# Altium schematic sheet Sheet1.SchDoc
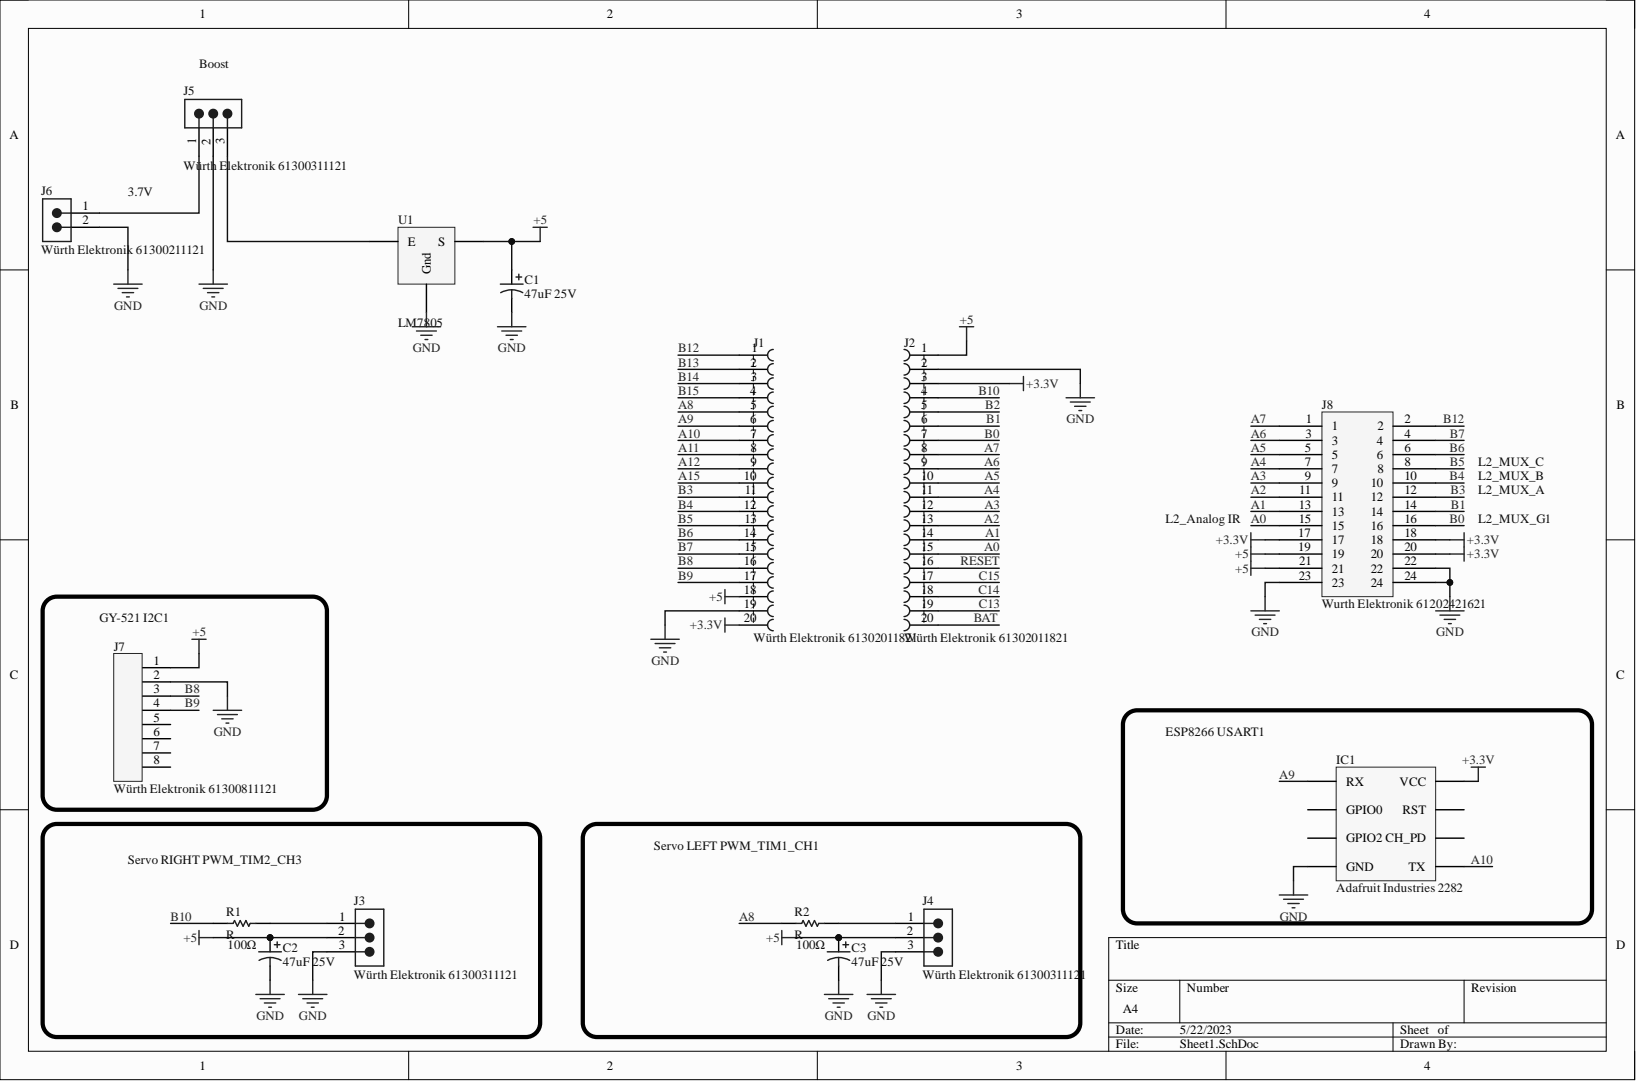
[diagram p0: Sheet1.SchDoc]

## Parts
C1  47uF 25V  [ELCO-6.3-12-2.5]
C2  47uF 25V  [ELCO-6.3-12-2.5]
C3  47uF 25V  [ELCO-6.3-12-2.5]
IC1  Adafruit Industries 2282  Manufacturer Part Number: 2282  [PCB-8dog99dypeufmvpps9vj-1]
J1  Würth Elektronik 61302011821  [PCB-ze9tk72dnivqf9rwp0x5-1]
J2  Würth Elektronik 61302011821  Manufacturer Part Number: 61302011821  [PCB-ze9tk72dnivqf9rwp0x5-1]
J3  Würth Elektronik 61300311121  [Würth Elektronik 61300311121-Footprint-1]
J4  Würth Elektronik 61300311121  [Würth Elektronik 61300311121-Footprint-1]
J5  Würth Elektronik 61300311121  [Würth Elektronik 61300311121-Footprint-1]
J6  Würth Elektronik 61300211121  [Würth Elektronik 61300211121-Footprint-1]
J7  Würth Elektronik 61300811121  [PCB-mwg13fs3p401tzikccr9-1]
J8  Wurth Elektronik 61202421621  Manufacturer Part Number: 61202421621  [Wurth Elektronik 61202421621-Footprint-1]
R1  R  [PCB-q66ntzze7gytxksj4v9p-1]
R2  R  [PCB-q66ntzze7gytxksj4v9p-1]
U1  LM7805  [PCB-8j54e42cjq8e6smjudro-5]

## Nets
+3.3V: IC1.4(VCC) J1.20 J2.3 J8.17 J8.18 J8.20
+5: C1.1 C2.1 C3.1 J1.18 J2.1 J3.2 J4.2 J7.1 J8.19 J8.21 U1.S
GND: C1.2 C2.2 C3.2 IC1.8(GND) J1.19 J2.2 J3.3 J4.3 J5.2 J6.2 J7.2 J8.22 J8.23 J8.24 U1.Gnd
A0: J2.15 J8.15
A1: J2.14 J8.13
A2: J2.13 J8.11
A3: J2.12 J8.9
A4: J2.11 J8.7
A5: J2.10 J8.5
A6: J2.9 J8.3
A7: J2.8 J8.1
A8: J1.5 R2.1
A9: IC1.5(RX) J1.6
A10: IC1.1(TX) J1.7
A11: J1.8
A12: J1.9
A15: J1.10
B0: J2.7 J8.16
B1: J2.6 J8.14
B2: J2.5
B3: J1.11 J8.12
B4: J1.12 J8.10
B5: J1.13 J8.8
B6: J1.14 J8.6
B7: J1.15 J8.4
B8: J1.16 J7.3
B9: J1.17 J7.4
B10: J2.4 R1.1
B12: J1.1 J8.2
B13: J1.2
B14: J1.3
B15: J1.4
BAT: J2.20
C13: J2.19
C14: J2.18
C15: J2.17
RESET: J2.16
NetJ3_1: J3.1 R1.2
NetJ4_1: J4.1 R2.2
NetJ5_1: J5.1 J6.1
NetJ5_3: J5.3 U1.E

Not connected: IC1 2(CH_PD) 3(RST) 6(GPIO0) 7(GPIO2); J7 5 6 7 8

## Library data of the parts
C1-C3 = CMP-f0e23b7c3dc72f25-3: 150uF 6.3mm diameter 2.5mm lead spacing; Manufacturer Part Number: ECE-A1EKA470I; Capacitance: 47.0 µF; Capacitance Tolerance: ±20%; Lead-Free Status: Lead Free; Voltage Rating (DC): 25.0 V; Component Kind: Standard; Library Name: My Personal Space; Lifecycle Status: Not Listed by Manufacturer; Octopart Url: https://octopart.com/ece-a1eka470i-panasonic-43313219; OctopartID: f0e23b7c3dc72f25; Operating Temperature: -40.0 °C (min); Packaging: Cut Tape (CT); REACH SVHC Compliance: No SVHC; RoHS: Compliant; Size-Diameter: 6.30 mm; Size-Height: 7.00 mm
IC1 = CMP-95455f7502034a82-7: Wiremold: Parcel Drops & CollectionFittings & Kits: : Salsbury Industries Mailboxes 2200 Series 2-Compartments Alumin...; Current consumption (Nom/Max): 70mA / 200mA; Voltage supply: 3.3V; Component Kind: Standard; Library Name: My Personal Space; Octopart URL: http://octopart.com/2282-adafruit+industries-54178336; OctopartID: 95455f7502034a82; RoHS: Non-Compliant
J1 J2 = CMP-f0823a38306c2f45-1: THT Vertical Socket Header WR-PHD, Pitch 2.54 mm, Single Row, 20 pins; Contact Resistance: 20.0 mΩ; Current Rating: 3.00 A; Dielectric Withstanding Voltage: 500 V; Gender: Female; Operating Voltage: 250 V; Pin Pitch: 2.54 mm; Component Kind: Standard; Contact Material: Copper Alloy; Contact Plating: Gold; Library Name: My Personal Space; Mounting Style: Through Hole; Number of Pins: 20; Octopart URL: https://octopart.com/61302011821-w%C3%BCrth+elektronik-61924744; OctopartID: f0823a38306c2f45; Operating Temperature: -40.0 °C (min); Orientation: Vertical; Packaging: Tray; RoHS: Compliant
  J1: Manufacturer Part Number: 61302011821
J3-J5 = CMP-8a90426ffa175557-46: THT Vertical Pin Header WR-PHD, Pitch 2.54 mm, Single Row, 3 pins; Contact Resistance: 20.0 mΩ; Current Rating: 3.00 A; Dielectric Withstanding Voltage: 500 V; Gender: Male; Lead-Free Status: Lead Free; Number of Contacts: 3; Operating Voltage: 250 V; Pin Pitch: 2.54 mm; Color: Black; Component Kind: Standard; Contact Material: Copper; Contact Plating: Gold; Library Name: My Personal Space; Manufacturer Part Number: 61300311121; Mounting Style: Through Hole; Number of Pins: 3; Octopart URL: https://octopart.com/61300311121-w%C3%BCrth+elektronik-32855521; OctopartID: 8a90426ffa175557; Operating Temperature: -40.0 °C (min); Orientation: Vertical; Packaging: Bag; REACH SVHC Compliance: No SVHC; RoHS: Compliant
J6 = CMP-748fbcb73d6bab48-32: THT Vertical Pin Header WR-PHD, Pitch 2.54 mm, Single Row, 2 pins; Contact Resistance: 20.0 mΩ; Current Rating: 3.00 A; Dielectric Withstanding Voltage: 500 V; Gender: Male; Lead-Free Status: Lead Free; Number of Contacts: 2; Operating Voltage: 250 V; Pin Pitch: 2.54 mm; Color: Black; Component Kind: Standard; Contact Material: Copper; Contact Plating: Gold; Library Name: My Personal Space; Manufacturer Part Number: 61300211121; Mounting Style: Through Hole; Number of Pins: 2; Octopart URL: https://octopart.com/61300211121-wurth+elektronik-20081794?r=sp; Operating Temperature: 125 °C (max); Orientation: Vertical; Packaging: Bag; REACH SVHC Compliance: No SVHC; RoHS: Compliant
J7 = CMP-14614025-11: THT Vertical Pin Header WR-PHD, Pitch 2.54 mm, Single Row, 8 pins; Contact Resistance: 20.0 mΩ; Current Rating: 3.00 A; Dielectric Withstanding Voltage: 500 V; Gender: Male; Number of Contacts: 8; Operating Voltage: 250 V; Pin Pitch: 2.54 mm; Color: Black; Component Kind: Standard; Contact Material: Copper; Contact Plating: Gold; Library Name: My Personal Space; Manufacturer Part Number: 61300811121; Mounting Style: Through Hole; Number of Pins: 8; Octopart URL: https://octopart.com/61300811121-w%C3%BCrth+elektronik-32855533; OctopartID: 79cbb1340940a60c; Operating Temperature: -40.0 °C (min); Orientation: Vertical; Packaging: Bag; REACH SVHC Compliance: No SVHC; RoHS: Compliant
J8 = CMP-5c4c94b32c98838b-3: WR-BHD Straight Male, Box Header, Through Hole, 2 Rows, 24 Contacts, 2.54mm Pitch; Contact Resistance: 20.0 mΩ; Dielectric Withstanding Voltage: 500 V; Number of Contacts: 24; Operating Voltage: 250 V; Color: Black; Component Kind: Standard; Contact Material: Copper; Contact Plating: Gold; Gender: Male; Library Name: My Personal Space; Mounting Style: Through Hole; Number of Pins: 24; Octopart Url: https://octopart.com/61202421621-wurth+elektronik-32855474; OctopartID: 5c4c94b32c98838b; Operating Temperature: -40.0 °C (min); Orientation: Vertical; Packaging: Tray; Pin Pitch: 2.54 mm; REACH SVHC Compliance: No SVHC; RoHS: Compliant
R1 R2 = CMP-d70a1ef5a19f08cd-8: Res Metal Film 1K Ohm 1% 3/5W ±50ppm/°C Conformal AXL Thru-Hole Ammo Pack; Resistance: 100Ω; Component Kind: Standard; Library Name: My Personal Space; Mounting Style: Axial; OctopartID: d70a1ef5a19f08cd; Resistance Tolerance: ±1%
U1 = CMP-15a8dcaa280fca6f-34: LM78XX Series 5 V  TO-220; Manufacturer Part Number: LM7805CT; Current Rating: 1.00 A; Dropout Voltage: 2.00 V; Input Voltage (DC): 35.0 V (max); Lead-Free Status: Lead Free; Number of Outputs: 1; Output Capacitor Type: Ceramic; Output Voltage: 5.20 V, 5.00 V; Quiescent Current: 8.00 mA; Case/Package: TO-220; Component Kind: Standard; Library Name: My Personal Space; Lifecycle Status: Active; Mounting Style: Through Hole; Number of Pins: 3; Number of Regulated Outputs: 1; Octopart URL: http://octopart.com/lm7805ct-fairchild+semiconductor-530625; OctopartID: 15a8dcaa280fca6f; Output Current: 1.00 A; Packaging: Tube; REACH SVHC Compliance: No SVHC; RoHS: Compliant
